# Altium schematic sheet MCU.SchDoc(MCU)
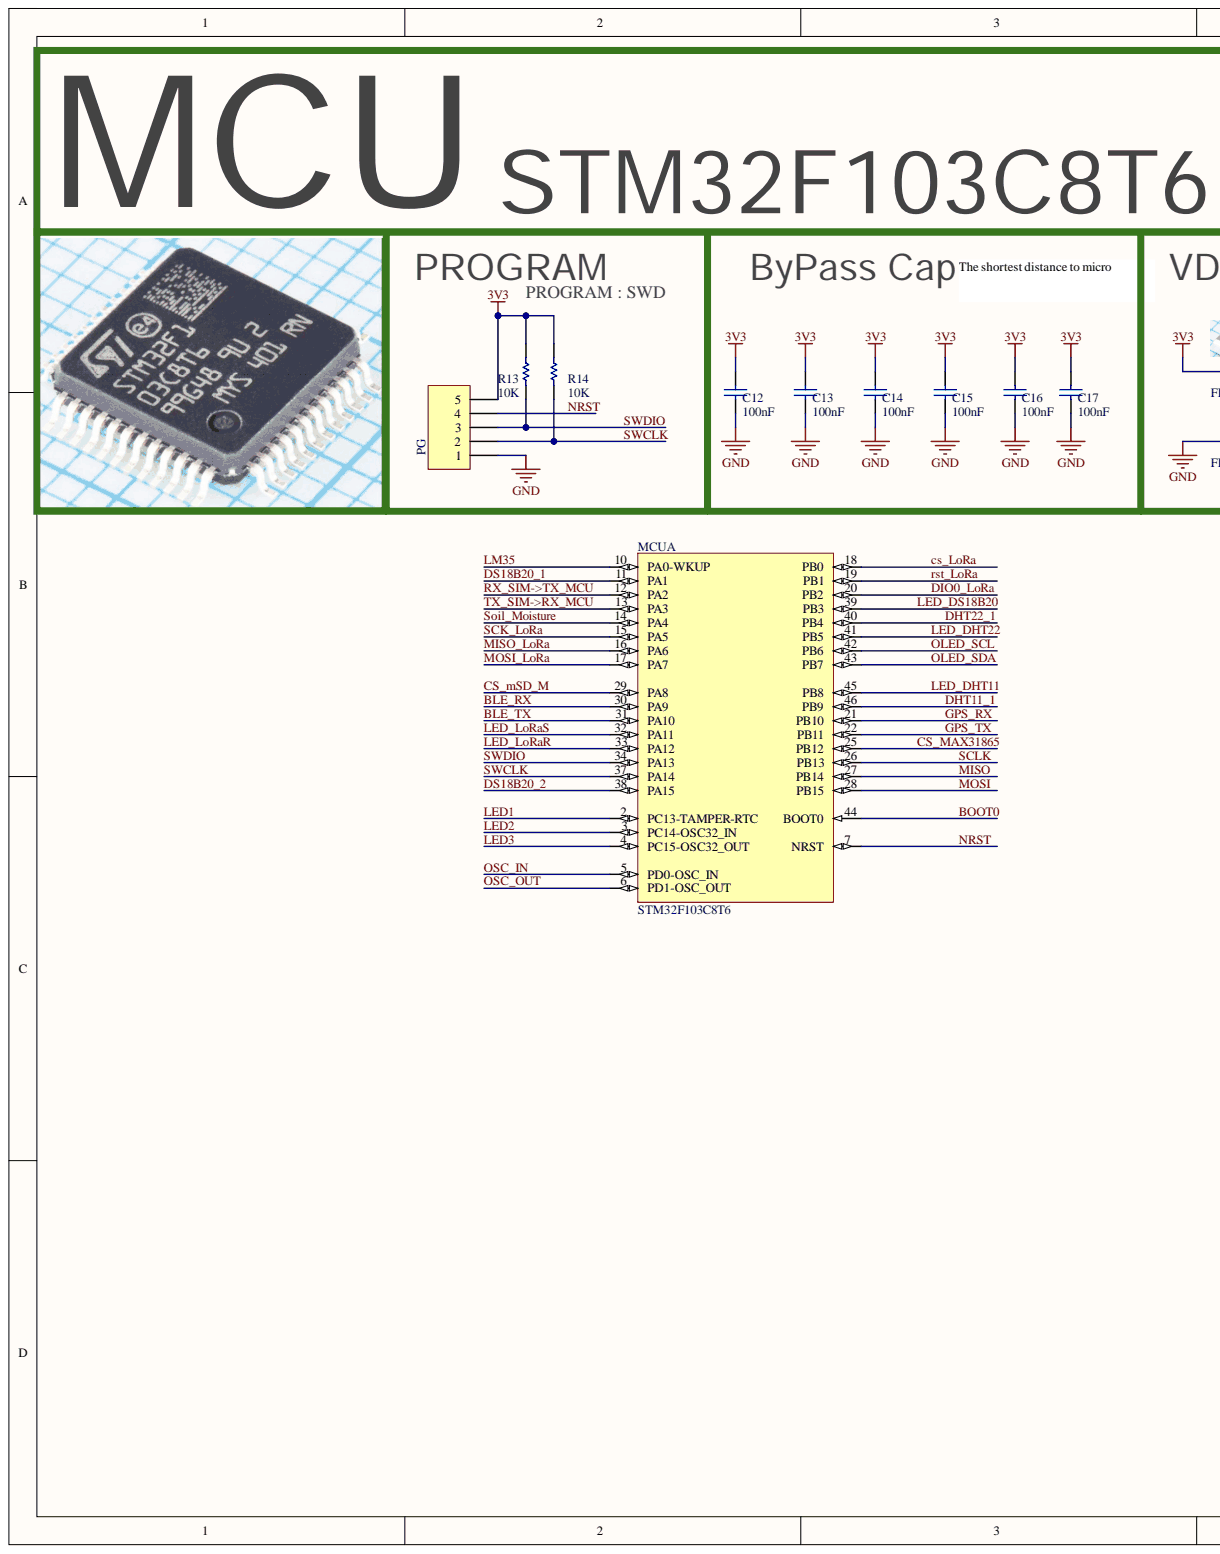
[diagram p0: MCU.SchDoc, left]
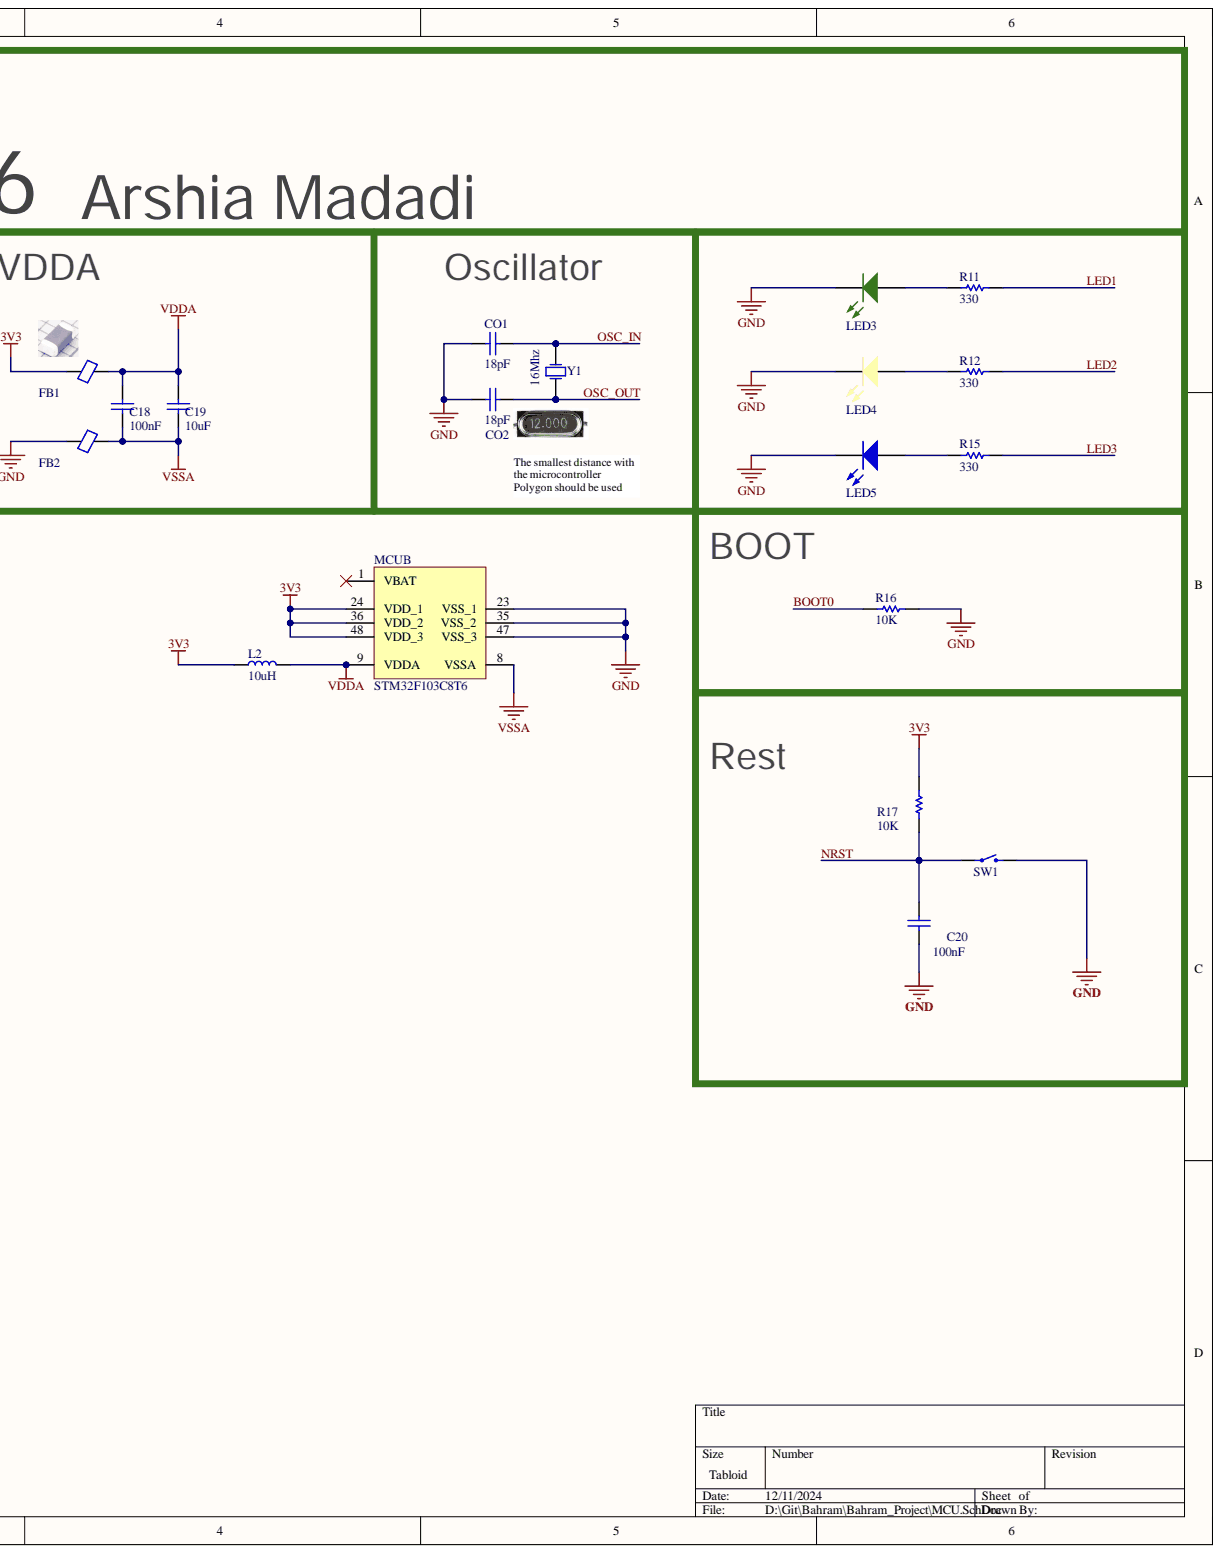
[diagram p1: MCU.SchDoc, right]

## Parts
C12  100nF  [MY_CAP_SMD_0603]
C13  100nF  [MY_CAP_SMD_0603]
C14  100nF  [MY_CAP_SMD_0603]
C15  100nF  [MY_CAP_SMD_0603]
C16  100nF  [MY_CAP_SMD_0603]
C17  100nF  [MY_CAP_SMD_0603]
C18  100nF  [MY_CAP_SMD_0603]
C19  10uF  [MY_CAP_SMD_0603]
C20  100nF  [MY_CAP_SMD_0603]
CO1  18pF  [MY_CAP_SMD_0805]
CO2  18pF  [MY_CAP_SMD_0805]
FB1  Ferrite Bead SMD  [FB1206]  (value not drawn)
FB2  Ferrite Bead SMD  [FB1206]  (value not drawn)
L2  10uH  [IND 1206_3216]
LED3  LED SMD 0805 GREEN  [LED_0805_GREEN]  (value not drawn)
LED4  LED SMD 0805 WHITE  [LED_0805_WHITE]  (value not drawn)
LED5  LED SMD 0805 BLUE  [LED_0805_RED]  (value not drawn)
MCU  STM32F103C8T6  [QFP50P900X900X160-48N]  (parts A,B)
PG  Header 5  [My_1X05]  (value not drawn)
R11  330  [RESC3413X04N]
R12  330  [RESC3413X04N]
R13  10K  [RESC3413X04N]
R14  10K  [RESC3413X04N]
R15  330  [RESC3413X04N]
R16  10K  [RESC3413X04N]
R17  10K  [RESC3413X04N]
SW1  Tactile 2PIN 6X3.5mm H4.57mm (SMD)  [SWPBS_SPST_6X3X5]  (value not drawn)
Y1  16Mhz  [Crystal]

## Nets
3V3: C12.1 C13.1 C14.1 C15.1 C16.1 C17.1 FB1.1 L2.1 MCU.24(VDD_1) MCU.36(VDD_2) MCU.48(VDD_3) PG.5 R13.1 R14.1 R17.1
GND: C12.2 C13.2 C14.2 C15.2 C16.2 C17.2 C20.2 CO1.2 CO2.2 FB2.1 LED3.2 LED4.2 LED5.2 MCU.23(VSS_1) MCU.35(VSS_2) MCU.47(VSS_3) PG.1 R16.2 SW1.2
VDDA: C18.1 C19.1 FB1.2 L2.2 MCU.9(VDDA)
VSSA: C18.2 C19.2 FB2.2 MCU.8(VSSA)
BLE_RX: MCU.30(PA9)
BLE_TX: MCU.31(PA10)
BOOT0: MCU.44(BOOT0) R16.1
cs_LoRa: MCU.18(PB0)
CS_MAX31865: MCU.25(PB12)
CS_mSD_M: MCU.29(PA8)
DHT11_1: MCU.46(PB9)
DHT22_1: MCU.40(PB4)
DIO0_LoRa: MCU.20(PB2)
DS18B20_1: MCU.11(PA1)
DS18B20_2: MCU.38(PA15)
GPS_RX: MCU.21(PB10)
GPS_TX: MCU.22(PB11)
LED1: MCU.2(PC13-TAMPER-RTC) R11.1
LED2: MCU.3(PC14-OSC32_IN) R12.1
LED3: MCU.4(PC15-OSC32_OUT) R15.1
LED_DHT11: MCU.45(PB8)
LED_DHT22: MCU.41(PB5)
LED_DS18B20: MCU.39(PB3)
LED_LoRaR: MCU.33(PA12)
LED_LoRaS: MCU.32(PA11)
LM35: MCU.10(PA0-WKUP)
MISO: MCU.27(PB14)
MISO_LoRa: MCU.16(PA6)
MOSI: MCU.28(PB15)
MOSI_LoRa: MCU.17(PA7)
NRST: C20.1 MCU.7(NRST) PG.4 R17.2 SW1.1
OLED_SCL: MCU.42(PB6)
OLED_SDA: MCU.43(PB7)
OSC_IN: CO1.1 MCU.5(PD0-OSC_IN) Y1.2
OSC_OUT: CO2.1 MCU.6(PD1-OSC_OUT) Y1.1
rst_LoRa: MCU.19(PB1)
RX_SIM->TX_MCU: MCU.12(PA2)
SCK_LoRa: MCU.15(PA5)
SCLK: MCU.26(PB13)
Soil_Moisture: MCU.14(PA4)
SWCLK: MCU.37(PA14) PG.2 R14.2
SWDIO: MCU.34(PA13) PG.3 R13.2
TX_SIM->RX_MCU: MCU.13(PA3)
NetLED3_1: LED3.1 R11.2
NetLED4_1: LED4.1 R12.2
NetLED5_1: LED5.1 R15.2

Not connected: MCU 1(VBAT)

## Library data of the parts
C12-C20 = CAP SMD_0603: 1.6 mm × 0.8 mm; Component Kind: Standard; Library Name: AMINLIB.IntLib
CO1 CO2 = CAP SMD_0805: 2.0 mm × 1.25 mm; Component Kind: Standard; Library Name: AMINLIB.IntLib
FB1 FB2 = Ferrite Bead SMD Package: Designer: Ali Hafizi; Component Kind: Standard; Description: Ferrite Bead SMD; Library Name: Altium.IntLib
L2 = Ind 1206: SMT Inductor; Component Kind: Standard; Library Name: Inductor.IntLib; Value: 10uH
MCU = STM32F103C8T6: ARM Cortex-M3 32-bit MCU, 64 KB Flash, 20 KB Internal RAM, 37 I/Os, 48-pin LQFP, -40 to 85 degC, Tray; A/D Converter: 2x12-bit (10 Channel); FLASH Size (Prog) (kB): 64; I/Os (High Current): 37; Internal RAM Size (kB): 20; Operating Frequency(F) (Processor speed) (MHz): 72; Supply Voltage(Vcc) min (V): 2; Component Kind: Standard; ComponentLink1Description: Manufacturer URL; ComponentLink1URL: http://www.st.com/web/en/home.html; ComponentLink2Description: Datasheet; ComponentLink2URL: http://www.st.com/st-web-ui/static/active/en/resource/technical/document/datasheet/CD00161566.pdf; ComponentLink3Description: Package Specification; ComponentLink3URL: http://www.st.com/st-web-ui/static/active/en/resource/technical/document/datasheet/DM00058181.pdf; Core: ARM Cortex-M3; DatasheetVersion: Rev. 16; Library Name: STMicroelectronics STM32 F1.IntLib; Mounting Technology: Surface Mount; PackageDescription: 48-Pin Low Profile Quad Flat Package, 7 x 7 mm Body, 0.5 mm Pitch; PackageReference: LQFP48; PackageVersion: Rev. 6, 06/2013; Packing: Tray; PartNumber: STM32F103C8T6; Supplier 1: Digi-Key; Supplier 2: Farnell; Supplier 3: Newark; Supplier 4: Newark; Supplier 5: Mouser; Supplier Part Number 1: 497-6063-ND; Supplier Part Number 2: 1447637; Supplier Part Number 3: 59M2182; Supplier Part Number 4: 57P1222; Supplier Part Number 5: 511-STM32F103C8T6
PG = Header 5: Header, 5-Pin; Component Kind: Standard; LatestRevisionDate: 17-Jul-2002; LatestRevisionNote: Re-released for DXP Platform.; Signal Integrity: Connector; Library Name: AMINLIB.IntLib; Publisher: Altium Limited
R11-R17 = RES 0805 0.125W: RES 0805; Desc.: RES SMD 0805; Designer: Abbas Basereh; Component Kind: Standard; Data: 16-12-2016; Library Name: Altium.IntLib
SW1 = Tactile 2PIN 6X3.5mm H4.57mm (SMD): Tactile Switch SPST-NO Top Actuated Surface Mount; PartNumber: FSMSMTR-ND; Component Kind: Standard; Library Name: Switch.IntLib
Y1 = XTAL: Crystal Oscillator; Component Kind: Standard; LatestRevisionDate: 17-Jul-2002; LatestRevisionNote: Re-released for DXP Platform.; PackageReference: R38; Published: 8-Jun-2000; Library Name: Sajad_Altium_Library.IntLib; Publisher: Altium Limited
LED3 = LED SMD 0805 GREEN: Component Kind: Standard; Library Name: Led.IntLib
LED4 = LED SMD 0805 WHITE: Component Kind: Standard; Library Name: Led.IntLib
LED5 = LED SMD 0805 BLUE: Component Kind: Standard; Library Name: Led.IntLib
